# Altium schematic sheet KETCube_2.SchDoc
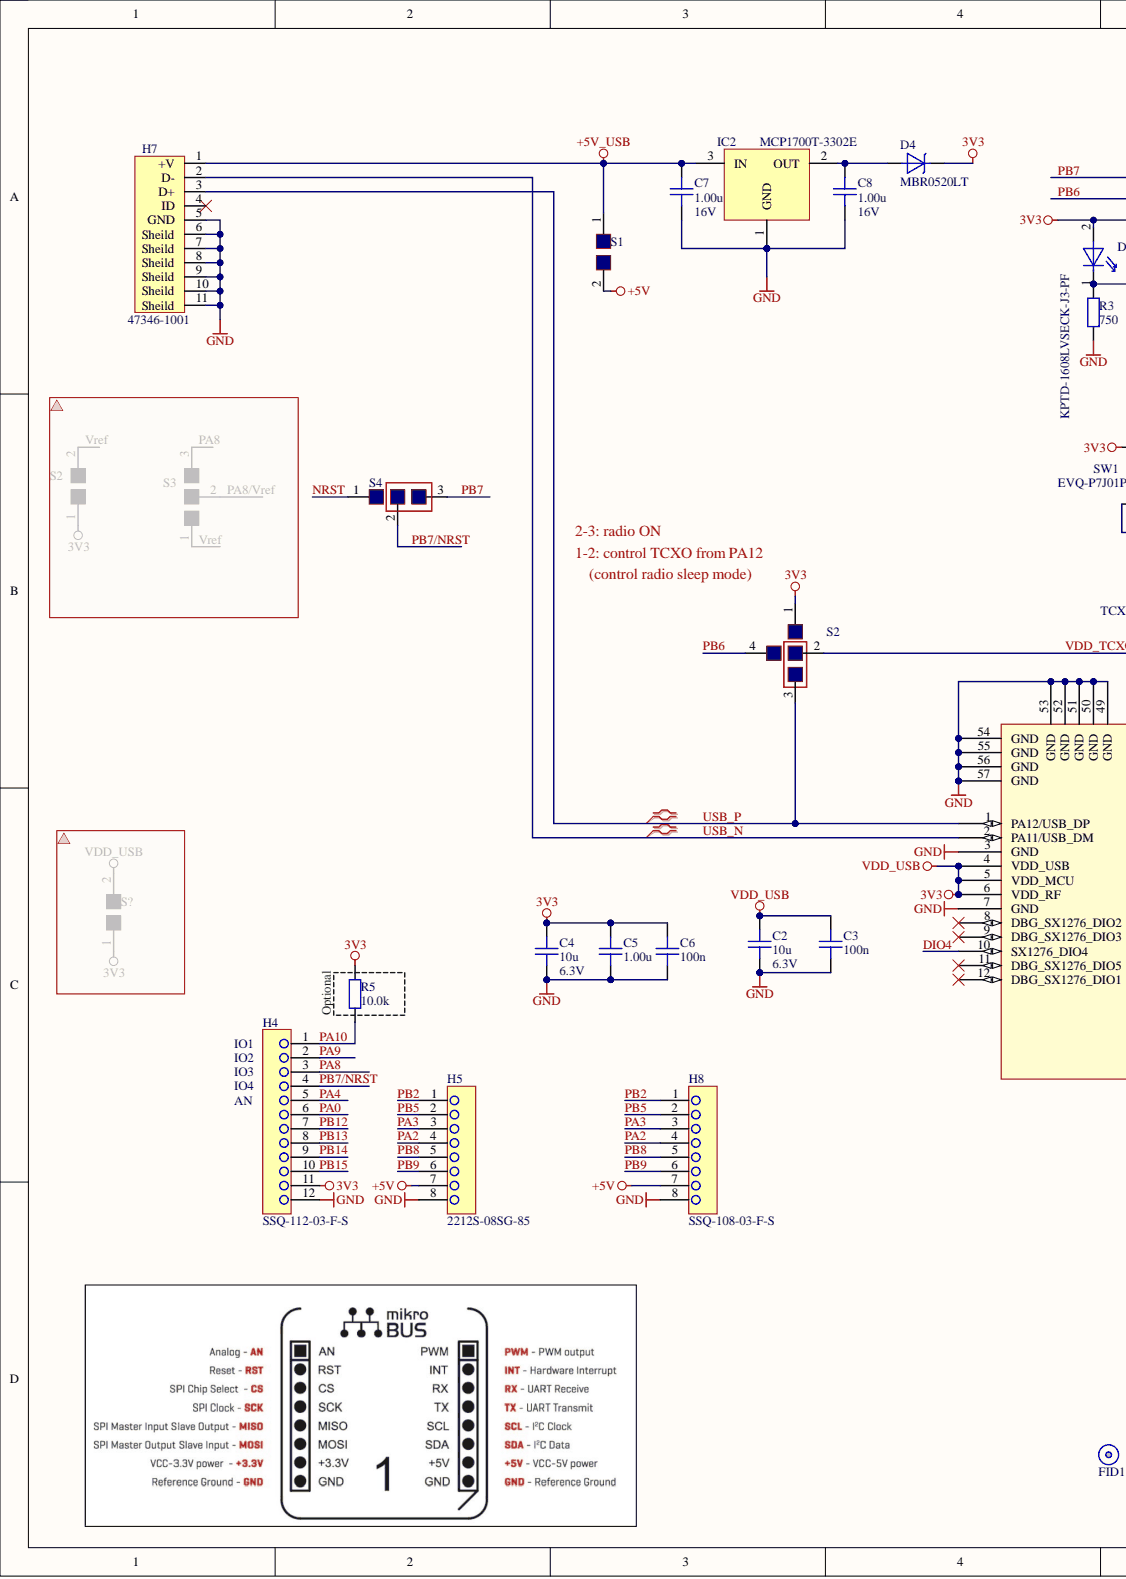
[diagram p0: KETCube_2.SchDoc, left]
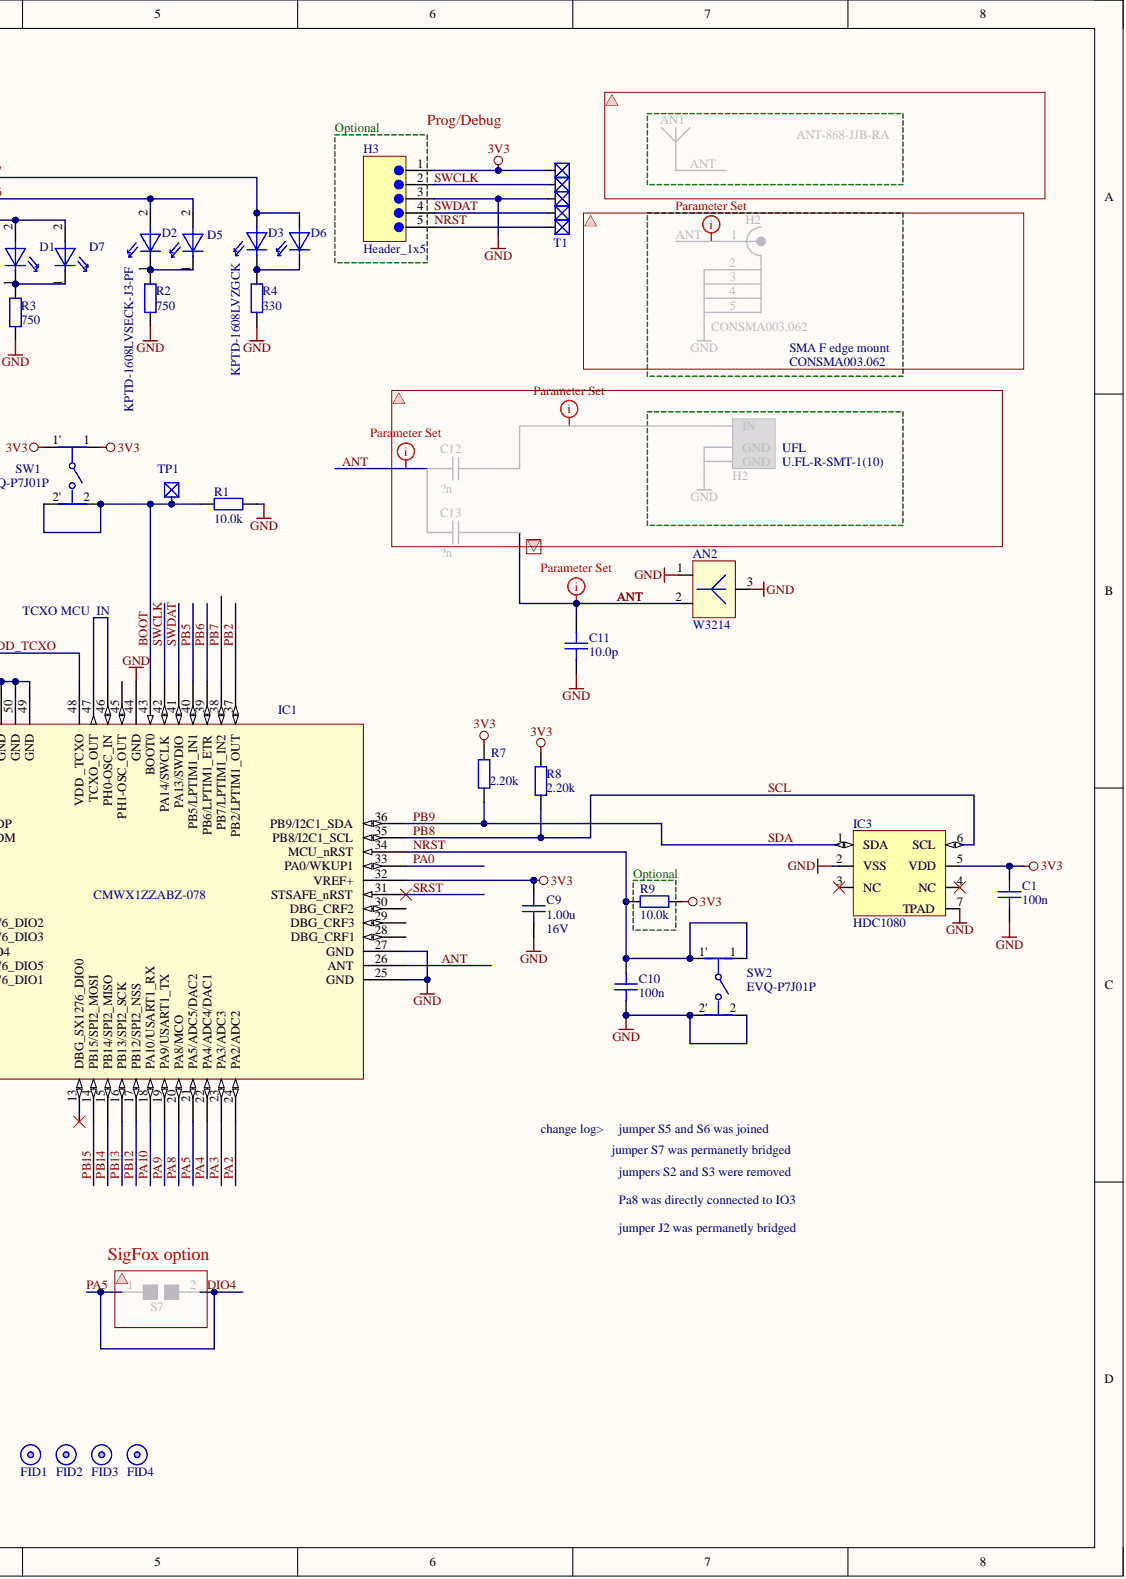
[diagram p1: KETCube_2.SchDoc, right]

## Parts
AN2  value W3214  Manuf Part Number: W3214  [SM_ANT_W3214]  (hidden comment: ant)
C1  100n  [sm_cap_0603]
C2  10u  Voltage: 6.3V  [sm_cap_0603]
C3  100n  [sm_cap_0603]
C4  10u  Voltage: 6.3V  [sm_cap_0603]
C5  1.00u  [sm_cap_0603]
C6  100n  [sm_cap_0603]
C7  1.00u  Voltage: 16V  [sm_cap_0603]
C8  1.00u  Voltage: 16V  [sm_cap_0603]
C9  1.00u  Voltage: 16V  [sm_cap_0603]
C10  100n  [sm_cap_0603]
C11  10.0p  [sm_cap_0603]
D1  KPTD-1608LVSECK-J3-PF  [sm_opt_led0603_h37_opp]
D2  KPTD-1608LVSECK-J3-PF  [sm_opt_led0603_h37_opp]
D3  KPTD-1608LVZGCK  [sm_opt_led0603_h37]
D4  MBR0520LT  [SM_DIO_SOD123]
D5  KPTD-1608LVSECK-J3-PF  [sm_opt_led0603_h37_opp]
D6  KPTD-1608LVZGCK  [sm_opt_led0603_h37]
D7  KPTD-1608LVSECK-J3-PF  [sm_opt_led0603_h37_opp]
FID1  Fiducial  [SM_mch_FIDUCIAL_32]  (value not drawn)
FID2  Fiducial  [SM_mch_FIDUCIAL_32]  (value not drawn)
FID3  Fiducial  [SM_mch_FIDUCIAL_32]  (value not drawn)
FID4  Fiducial  [SM_mch_FIDUCIAL_32]  (value not drawn)
H3  Header_1x5  [TH_CON_HDR1X5_PIT50_RIGHT]
H4  SSQ-112-03-F-S  [TH_CON_REC1x12_PIT100_L400]
H5  2212S-08SG-85  [TH_CON_REC1x8_PIT100]
H7  47346-1001  [SM_CON_USB_MICRO_B_FEMALE_47346_1001]
H8  SSQ-108-03-F-S  [TH_CON_REC1x8_PIT100_L400]
IC1  CMWX1ZZABZ-078  [TH_MOD_PIN48_LoRa_Murata]
IC2  MCP1700T-3302E  [sm_io_sot23_pin3]
IC3  HDC1080  [SM_IO_WSON6_PIT40]
R1  10.0k  [sm_res_0603]
R2  750  [sm_res_0603]
R3  750  [sm_res_0603]
R4  330  [sm_res_0603]
R5  10.0k  [sm_res_0603]
R7  2.20k  [sm_res_0603]
R8  2.20k  [sm_res_0603]
R9  10.0k  [sm_res_0603]
S1  Solder jumper 0603  [SM_SOLDER_JUMPER_2PIN_0603]  (value not drawn)  (no BOM)
S2  SMD jumper  [SM_SOLDER_JUMPER_4PIN]  (value not drawn)  (no BOM)
S4  SMD jumper  [SM_SOLDER_JUMPER_3PIN]  (value not drawn)  (no BOM)
SW1  EVQ-P7J01P  [FP-EVQ-P7J01P-MFG]
SW2  EVQ-P7J01P  [FP-EVQ-P7J01P-MFG]
T1  TP40_5_pit50  [sm_mch_5pin_pit50]  (value not drawn)  (no BOM)
TP1  TP40  [sm_mch_test40]  (value not drawn)  (no BOM)

## Nets
3V3: C1.2 C2.2 C3.2 C4.2 C5.2 C6.2 C9.2 D1.2 D4.2 D7.2 H3.1 H4.11 IC1.4(VDD_USB) IC1.5(VDD_MCU) IC1.6(VDD_RF) IC1.32(VREF+) IC3.5(VDD) R5.1 R7.2 R8.2 R9.1 S2.1 SW1.1 SW1.1' T1.1
+5V: H5.7 H8.7 S1.2
+5V_USB: C7.2 H7.1(+V) IC2.3 S1.1
GND: AN2.1 AN2.3 C1.1 C2.1 C3.1 C4.1 C5.1 C6.1 C7.1 C8.1 C9.1 C10.1 C11.1 H3.3 H4.12 H5.8 H7.5(GND) H7.6(Sheild) H7.7(Sheild) H7.8(Sheild) H7.9(Sheild) H7.10(Sheild) H7.11(Sheild) H8.8 IC1.3(GND) IC1.7(GND) IC1.25(GND) IC1.27(GND) IC1.44(GND) IC1.49(GND) IC1.50(GND) IC1.51(GND) IC1.52(GND) IC1.53(GND) IC1.54(GND) IC1.55(GND) IC1.56(GND) IC1.57(GND) IC2.1 IC3.2(VSS) IC3.7(TPAD) R1.1 R2.2 R3.1 R4.2 SW2.2 SW2.2' T1.3
ANT: AN2.2 C11.2 IC1.26(ANT)
BOOT: IC1.43(BOOT0) R1.2 SW1.2(2') SW1.2' TP1.1
DIO4: IC1.10(SX1276_DIO4) IC1.21(PA5/ADC5/DAC2)
NRST: C10.2 H3.5 IC1.34(MCU_nRST) R9.2 S4.1 SW2.1 SW2.1' T1.5
PA0: H4.6 IC1.33(PA0/WKUP1)
PA2: H5.4 H8.4 IC1.24(PA2/ADC2)
PA3: H5.3 H8.3 IC1.23(PA3/ADC3)
PA4: H4.5 IC1.22(PA4/ADC4/DAC1)
PA8: H4.3 IC1.20(PA8/MCO)
PA9: H4.2 IC1.19(PA9/USART1_TX)
PA10: H4.1 IC1.18(PA10/USART1_RX) R5.2
PB2: H5.1 H8.1 IC1.37(PB2/LPTIM1_OUT)
PB5: H5.2 H8.2 IC1.40(PB5/LPTIM1_IN1)
PB6: D2.2 D5.2 IC1.39(PB6/LPTIM1_ETR) S2.4
PB7: D3.1 D6.1 IC1.38(PB7/LPTIM1_IN2) S4.3
PB7/NRST: H4.4 S4.2
PB8: H5.5 H8.5 IC1.35(PB8/I2C1_SCL) IC3.6(SCL) R8.1
PB9: H5.6 H8.6 IC1.36(PB9/I2C1_SDA) IC3.1(SDA) R7.1
PB12: H4.7 IC1.17(PB12/SPI2_NSS)
PB13: H4.8 IC1.16(PB13/SPI2_SCK)
PB14: H4.9 IC1.15(PB14/SPI2_MISO)
PB15: H4.10 IC1.14(PB15/SPI2_MOSI)
SRST: IC1.31(STSAFE_nRST)
SWCLK: H3.2 IC1.42(PA14/SWCLK) T1.2
SWDAT: H3.4 IC1.41(PA13/SWDIO) T1.4
USB_N: H7.2(D-) IC1.2(PA11/USB_DM)
USB_P: H7.3(D+) IC1.1(PA12/USB_DP) S2.3
VDD_TCXO: IC1.48(VDD__TCXO) S2.2
NetC8_2: C8.2 D4.1 IC2.2
NetD1_1: D1.1 D7.1 R3.2
NetD2_1: D2.1 D5.1 R2.1
NetD3_2: D3.2 D6.2 R4.1
NetIC1_46: IC1.46(PH0-OSC_IN) IC1.47(TCXO_OUT)

Not connected: H7 4(ID); IC1 8(DBG_SX1276_DIO2) 9(DBG_SX1276_DIO3) 11(DBG_SX1276_DIO5) 12(DBG_SX1276_DIO1) 13(DBG_SX1276_DIO0) 28(DBG_CRF1) 29(DBG_CRF3) 30(DBG_CRF2) 45(PH1-OSC_OUT); IC3 3(NC) 4(NC)

## Library data of the parts
AN2 = MOD_000054: MOD antenna CHIP 863-873 MHz, ISM, IoT, 10x3.2x5mm; Manufacturer: Pulse Electronics; ManufacturerID: Pulse; Part Select: SMOD0006000000000000; Supp Part number: 673-W3214; Supp Part number 2: 1837-1154-1-ND; Active: 1; Database Table Name: _Modules; Designer: Jiri Cengery; Exact price 2: 0; FIT: 2; ID: 7218; LasrRevisionNote: update; Max Oper Temperature: 85; Min Oper Temperature: -40; PCB Status: electrical; Publishied: 15,9,2019; Alternative: 1; Cat1: Antenna; Component Kind: Standard; ComponentLink1Description: Datasheet; ComponentLink1URL: https://riceproject.fel.zcu.cz/Altium/PDF/Passive/antennas/PulseLarsen/2719935.pdf; ComponentLink2Description: Antenna 868MHz; Exact price: 1; Exact price 3: 0; LastRevisionDate: 31.10.2019; Library Name: Lib_Components_SQL.DbLib; ManufacturerURL: http://www.pulseelectronics.com; Part Category: Antenna; Part Number: MOD_000054; Part type: MOD; PartNumber: MOD_000054; PartQuantity: 1; Preference: 0; Price: 41; Price 2: 41; Price 3: 0; RoHS: 1; Simulation: nosim; Stock: 0; Supplier: Mouser Electronics; Supplier2: Digikey; Supplier3: N/A; SupplierID: Mouser; SupplierID2: Digikey; SupplierID3: no supplier; SupplierURL: http://www.mouser.com; SupplierURL2: http://www.digikey.com/; SupplierURL3: N/A; Publisher: Jiri Cengery
C1 C3 C6 C10 = CAP_000000: CAP CER .10UF 50V X7R 10% 0603; Manuf Part Number: C1608X7R1H104K080AA; Manufacturer: TDK Corporation; ManufacturerID: TDK; Part Category: Ceramic/0603/X7R; Part Select: SCAP0001000300026100; Supp Part number: 445-1314-2-ND; Supp Part number 2: 1907318; Active: 1; Cat1: Ceramic; Cat2: 0603; Database Table Name: _Capacitors; Designer: Jiri  Cengery; Exact price 2: 0; FIT: 0,100000001490116; ID: 4; LasrRevisionNote: new release; Max Oper Temperature: 125; Min Oper Temperature: -55; PCB Status: Electrical; Preference: 100; Alternative: 1; Cat3: X7R; Component Kind: Standard; ComponentLink1Description: Datasheet; ComponentLink1URL: https://riceproject.fel.zcu.cz/Altium/PDF/passive\capacitors\Cxxxx_TDK.pdf; Dielectric: X7R; Exact price: 1; Exaxt price 3: 0; LastRevisionDate: 11.5.2011; Library Name: Lib_Components_SQL.DbLib; ManufacturerURL: http://www.tdk.com/; Part Number: CAP_000000; Part type: CAP; PartNumber: CAP_000000; PartQuantity: 1; PCB3D: sm_cap_0603; Price: 0,112099997699261; Price 2: 0,899999976158142; Price 3: 0; Publishied: 11.5.2011; Quantity: 1; ROHS: 1; Simulation: cap; Stock: 2901; Supplier: Digikey; Supplier2: Farnell; Supplier3: N/A; SupplierID: Digikey; SupplierID2: Farnell; SupplierID3: no supplier; SupplierURL: http://www.digikey.com/; SupplierURL2: http://cz.farnell.com/; SupplierURL3: N/A; Tolerance: 10%; Value: 100n; Voltage: 50V; Publisher: Libor Polacek
C2 C4 = CAP_000536: CAP CER 10uF 6.3V X5R 10% 0603; Manuf Part Number: GRM188R60J106KE47D; Manufacturer: Murata; Part Category: Ceramic/0603/X5R; Part Select: SCAP0001000300038100; Supp Part number: 2494230; Active: 1; Cat1: Ceramic; Cat2: 0603; Database Table Name: _Capacitors; Designer: Jiri  Cengery; Exact price 2: 0; FIT: 0,100000001490116; ID: 5366; LastRevisionNote: new release; Max Oper Temperature: 85; Min Oper Temperature: -55; PCB Status: Electrical; Alternative: 1; Cat3: X5R; Component Kind: Standard; ComponentLink1Description: Datasheet; ComponentLink1URL: https://riceproject.fel.zcu.cz/Altium/PDF/Passive\Capacitors\1955679.pdf; Dielectric: X5R; Exact price: 1; Exact price 3: 0; LastRevisionDate: 11.5.2011; Library Name: Lib_Components_SQL.DbLib; ManufacturerID: Murata; ManufacturerURL: http://www.murata.com/; Part Number: CAP_000536; Part type: CAP; PartNumber: CAP_000536; PartQuantity: 1; PCB3D: sm_cap_0603; Preference: 0; Price: 1; Price 2: 0; Price 3: 0; Published: 11.5.2011; RoHS: 1; Simulation: cap; Stock: 0; Supplier: Farnell; Supplier2: N/A; Supplier3: N/A; SupplierID: Farnell; SupplierID2: no supplier; SupplierID3: no supplier; SupplierURL: http://cz.farnell.com/; SupplierURL2: N/A; SupplierURL3: N/A; Tolerance: 10%; Value: 10u; Publisher: Libor Polacek
C5 C9 = CAP_000381: CAP CER 1.0UF 16V X7R 10% 0603; Manuf Part Number: C1608X7R1C105K; Manufacturer: TDK Corporation; ManufacturerID: TDK; Part Category: Ceramic/0603/X7R; Part Select: SCAP0001000300027100; Supp Part number: 445-1604-1-ND; Supp Part number 2: 1907343; Active: 1; Cat1: Ceramic; Cat2: 0603; Database Table Name: _Capacitors; Designer: Jiri  Cengery; Exact price 2: 0; FIT: 0,100000001490116; ID: 1567; LastRevisionNote: new release; Max Oper Temperature: 125; Min Oper Temperature: -55; PCB Status: Electrical; Alternative: 1; Cat3: X7R; Component Kind: Standard; ComponentLink1Description: Datasheet; ComponentLink1URL: https://riceproject.fel.zcu.cz/Altium/PDF/Passive\Capacitors\C_series_TDK.pdf; Dielectric: X7R; Exact price: 1; Exaxt price 3: 0; LastRevisionDate: 11.5.2011; Library Name: Lib_Components_SQL.DbLib; ManufacturerURL: http://www.tdk.com/; Part Number: CAP_000381; Part type: CAP; PartNumber: CAP_000381; PartQuantity: 1; PCB3D: sm_cap_0603; Preference: 0; Price: 1,10000002384186; Price 2: 25; Price 3: 0; Published: 11.5.2011; ROHS: 1; Simulation: cap; Stock: 3184; Supplier: Digikey; Supplier2: Farnell; Supplier3: N/A; SupplierID: Digikey; SupplierID2: Farnell; SupplierID3: no supplier; SupplierURL: http://www.digikey.com/; SupplierURL2: http://cz.farnell.com/; SupplierURL3: N/A; Tolerance: 10%; Value: 1.00u; Publisher: Libor Polacek
  C5: Voltage: 16V
C7 C8 = CAP_000381: CAP CER 1.0UF 16V X7R 10% 0603; Manuf Part Number: C1608X7R1C105K; Manufacturer: TDK Corporation; ManufacturerID: TDK; Part Category: Ceramic/0603/X7R; Part Select: SCAP0001000300027100; Supp Part number: 445-1604-1-ND; Supp Part number 2: 1907343; Active: 1; Cat1: Ceramic; Cat2: 0603; Database Table Name: _Capacitors; Designer: Jiri  Cengery; Exact price 2: 0; FIT: 0.100000001490116; ID: 1567; LastRevisionNote: new release; Max Oper Temperature: 125; Min Oper Temperature: -55; PCB Status: Electrical; Alternative: 1; Cat3: X7R; Component Kind: Standard; ComponentLink1Description: Datasheet; ComponentLink1URL: https://riceproject.fel.zcu.cz/Altium/PDF/Passive\Capacitors\C_series_TDK.pdf; Dielectric: X7R; Exact price: 1; Exact price 3: 0; LastRevisionDate: 11.5.2011; Library Name: Lib_Components_SQL.DbLib; ManufacturerURL: http://www.tdk.com/; Part Number: CAP_000381; Part type: CAP; PartNumber: CAP_000381; PartQuantity: 1; PCB3D: sm_cap_0603; Preference: 0; Price: 1.10000002384186; Price 2: 25; Price 3: 0; Published: 11.5.2011; RoHS: 1; Simulation: cap; Stock: 3181; Supplier: Digikey; Supplier2: Farnell; Supplier3: N/A; SupplierID: Digikey; SupplierID2: Farnell; SupplierID3: no supplier; SupplierURL: http://www.digikey.com/; SupplierURL2: http://cz.farnell.com/; SupplierURL3: N/A; Tolerance: 10%; Value: 1.00u; Publisher: Libor Polacek
C11 = CAP_000016: CAP CER 10.0p 50V C0G 5% 0603; Manuf Part Number: GRM1885C1H100JA01D; Manufacturer: Murata; Part Category: Ceramic/0603/COG/NPO; Part Select: SCAP0001000300012100; Supp Part number: 490-1403-2-ND; Active: 1; Cat1: Ceramic; Cat2: 0603; Cat3: COG/NPO; Database Table Name: _Capacitors; Designer: MaJa; FIT: 0,100000001490116; ID: 20; LasrRevisionNote: new release; Max Oper Temperature: 125; Min Oper Temperature: -55; PCB Status: Electrical; Alternative: 1; Component Kind: Standard; ComponentLink1Description: Datasheet; ComponentLink1URL: https://riceproject.fel.zcu.cz/Altium/PDF/passive/capacitors/GRMxxx_Murata.pdf; Dielectric: C0G; Exact price: 1; LastRevisionDate: 11.5.2011; Library Name: Lib_Components_SQL.DbLib; ManufacturerID: Murata; ManufacturerURL: http://www.murata.com/; Part Number: CAP_000016; Part type: CAP; PartNumber: CAP_000016; PartQuantity: 1; PCB3D: sm_cap_0603; Price: 0,103799998760223; Price 2: 0; Price 3: 0; Publishied: 11.5.2011; Quantity: 1; RoHS: 1; Simulation: cap; Stock: 45; Supplier: Digikey; Supplier2: N/A; Supplier3: N/A; SupplierID: Digikey; SupplierID2: no supplier; SupplierID3: no supplier; SupplierURL: http://www.digikey.com/; SupplierURL2: N/A; SupplierURL3: N/A; Tolerance: 5%; Value: 10.0p; Voltage: 50V; Publisher: Libor Polacek
D1 D2 D5 D7 = OPT_000081: OPT LED red 625nm 2mA 50mcd 1.8V 0603; Manufacturer: kingbright; Part Category: LED/0603; Part Select: SOPT0001000100000000; Supp Part number: 2610411; Active: 1; Cat2: 0603; Database Table Name: _Optoelectronics; Designer: Jiri  Cengery; Exact price: 0; FIT: 2; ID: 6052; LastRevisionNote: new release; Max Oper Temperature: 85; Min Oper Temperature: -40; PCB Status: Electrical; Alternative: 1; Cat1: LED; Color: red; Component Kind: Standard; ComponentLink1Description: Datasheet; ComponentLink1URL: https://riceproject.fel.zcu.cz/Altium/PDF/Semiconductor\Analog\LED\2068425.pdf; Exact price 2: 0; Exaxt price 3: 0; LastRevisionDate: 11.5.2011; Library Name: Lib_Components_SQL.DbLib; Manuf Part Number: KPTD-1608LVSECK-J3-PF; ManufacturerID: Kingbright; ManufacturerURL: www.kingbright.com; Part Number: OPT_000081; Part type: OPT; PartNumber: OPT_000081; PartQuantity: 1; PCB3D: sm_opt_led0603_h37_opp; Preference: 0; Price: 7; Price 2: 0; Price 3: 0; Published: 11.5.2011; Simulation: nosim; Stock: 0; Supplier: Farnell; Supplier2: N/A; Supplier3: N/A; SupplierID: Farnell; SupplierID2: no supplier; SupplierID3: no supplier; SupplierURL: http://cz.farnell.com/; SupplierURL2: N/A; SupplierURL3: N/A; Value: KPTD-1608LVSECK-J3-PF; Publisher: Libor Polacek
D3 D6 = OPT_000080: OPT LED green 525nm 2mA 220mcd 2.65V 0603; Manufacturer: kingbright; Part Category: LED/0603; Part Select: SOPT0001000100000000; Supp Part number: 2610414; Active: 1; Cat2: 0603; Database Table Name: _Optoelectronics; Designer: Jiri  Cengery; Exact price: 0; FIT: 2; ID: 6050; LastRevisionNote: new release; Max Oper Temperature: 85; Min Oper Temperature: -40; PCB Status: Electrical; Alternative: 1; Cat1: LED; Color: green; Component Kind: Standard; ComponentLink1Description: Datasheet; ComponentLink1URL: https://riceproject.fel.zcu.cz/Altium/PDF/Semiconductor\Analog\LED\2068428.pdf; Exact price 2: 0; Exaxt price 3: 0; LastRevisionDate: 11.5.2011; Library Name: Lib_Components_SQL.DbLib; Manuf Part Number: KPTD-1608LVZGCK; ManufacturerID: Kingbright; ManufacturerURL: www.kingbright.com; Part Number: OPT_000080; Part type: OPT; PartNumber: OPT_000080; PartQuantity: 1; PCB3D: sm_opt_led0603_h37; Preference: 0; Price: 8; Price 2: 0; Price 3: 0; Published: 11.5.2011; Simulation: nosim; Stock: 0; Supplier: Farnell; Supplier2: N/A; Supplier3: N/A; SupplierID: Farnell; SupplierID2: no supplier; SupplierID3: no supplier; SupplierURL: http://cz.farnell.com/; SupplierURL2: N/A; SupplierURL3: N/A; Value: KPTD-1608LVZGCK; Publisher: Libor Polacek
D4 = DIO_000074: DIO schottky  20V 0.5A SOD123; Manuf Part Number: MBR0520LT1G; Manufacturer: On Semiconductor; ManufacturerID: OnSemi; Part Select: SDIO0002000000000000; Supp Part number: MBR0520LCT-ND; Active: 1; Database Table Name: _Diodes; Designer: Libor Polacek; Exact price 2: 0; FIT: 2; ID: 4063; LastRevisionNote: new release; Max Oper Temperature: 125; Min Oper Temperature: -55; PCB Status: Electrical; Alternative: 1; Cat1: Schottky; Component Kind: Standard; ComponentLink1Description: Datasheet; ComponentLink1URL: https://riceproject.fel.zcu.cz/Altium/PDF/Semiconductor\Analog\Diode\MBR0520LT1-D.PDF; Current: 0.5A; Exact price: 1; Exaxt price 3: 0; LastRevisionDate: 11.5.2011; Library Name: Lib_Components_SQL.DbLib; ManufacturerURL: http://www.onsemi.com; Part Category: Schottky; Part Number: DIO_000074; Part type: DIO; PartNumber: DIO_000074; PartQuantity: 1; Preference: 0; Price: 7; Price 2: 0; Price 3: 0; Published: 11.5.2011; ROHS: 1; Simulation: nosim; Stock: 0; Supplier: Digikey; Supplier2: N/A; Supplier3: N/A; SupplierID: Digikey; SupplierID2: no supplier; SupplierID3: no supplier; SupplierURL: http://www.digikey.com/; SupplierURL2: N/A; SupplierURL3: N/A; Value: MBR0520LT; Voltage: 20V; Publisher: Libor Polacek
FID1-FID4 = MCH_000050: Fiducial local circle 0.8mm; Manuf Part Number: x; ManufacturerID: no manufacturer; Part Category: Virtual/Fiducial; Part Select: SMCH0002000200000000; Active: 1; Cat1: Virtual; Database Table Name: _Mechanicals; Designer: Libor Polacek; Exact price: 0; ID: 2608; LastRevisionNote: new release; Max Oper Temperature: 200; Min Oper Temperature: -60; Alternative: 1; Cat2: Fiducial; Component Kind: Standard; ComponentLink1Description: Datasheet; Exact price 2: 0; Exaxt price 3: 0; FIT: 0; Function Type: fiducial; LastRevisionDate: 8.9.2011; Library Name: Lib_Components_SQL.DbLib; Part Number: MCH_000050; Part type: MCH; PartNumber: MCH_000050; PartQuantity: 1; PCB3D: sm_vir_fiducial; PCB Status: Virtual; Preference: 0; Price: 0; Price 2: 0; Price 3: 0; Publishied: 8.9.2011; ROHS: 1; Simulation: nosim; Stock: 0; Supp Part number: x; Supplier: N/A; Supplier2: N/A; Supplier3: N/A; SupplierID: no supplier; SupplierID2: no supplier; SupplierID3: no supplier; SupplierURL: N/A; SupplierURL2: N/A; SupplierURL3: N/A; Value: Fiducial; Publisher: Libor Polacek
H3 = CON_000371: CON 1x5pin header 50mill, righ , L 4mm; Manuf Part Number: TMS-105-02-L-S-RA; Manufacturer: SAMTEC; Part Category: Pin rows/Header PIT50/Position; Part Select: TCON0001000600015001; Supp Part number: 2055114; Active: 1; Cat1: Pin rows; Cat2: Header PIT50; Cat3: Position; Database Table Name: _Connectors; Designer: Jiri  Cengery; Exact price 2: 0; FIT: 5; ID: 5632; Max Oper Temperature: 105; Min Oper Temperature: -40; PCB Status: Electrical; Alternative: 1; Component Kind: Standard; ComponentLink1Description: Datasheet; ComponentLink1URL: https://riceproject.fel.zcu.cz/Altium/PDF/Passive\Connectors\Samtec\1992060.pdf; Design: HEADER 50mill; Exact price: 1; Exaxt price 3: 0; LatestRevisionDate: 09-Sep-2011; LatestRevisionNote: Re-released for DXP Platform.; Library Name: Lib_Components_SQL.DbLib; ManufacturerID: SAMTEC; ManufacturerURL: http://www.samtec.com/; Part Number: CON_000371; Part type: CON; PartNumber: CON_000371; PartQuantity: 1; Pin: 5; Preference: 0; Price: 14; Price 2: 0; Price 3: 0; Published: 15.6.2011; ROHS: 1; Signal Integrity: Connector; Simulation: nosim; Stock: 0; Supplier: Farnell; Supplier2: N/A; Supplier3: N/A; SupplierID: Farnell; SupplierID2: no supplier; SupplierID3: no supplier; SupplierURL: http://cz.farnell.com/; SupplierURL2: N/A; SupplierURL3: N/A; Value: Header_1x5; Publisher: Altium Limited
H4 = CON_000361: CON Receptacle 1x10 100 mils, leads length 10mm; Manufacturer: SAMTEC; Part Category: Pin rows/Receptacle/long leads; Part Select: TCON0001000200022120; Supp Part number: 2283784; Active: 1; Cat1: Pin rows; Cat3: long leads; Database Table Name: _Connectors; Design: RECEPTACLE 100mill; Designer: Jiri  Cengery; Exact price 2: 0; FIT: 50; ID: 5578; Max Oper Temperature: 125; Min Oper Temperature: -55; PCB Status: Electrical; System: Connector; Alternative: 1; Cat2: Receptacle; Component Kind: Standard; ComponentLink1Description: Datasheet; ComponentLink1URL: https://riceproject.fel.zcu.cz/Altium/PDF/Passive\Connectors\Samtec\1680445_.pdf; Exact price: 1; Exaxt price 3: 0; LatestRevisionDate: 13.10.2017; LatestRevisionNote: New Release; Library Name: Lib_Components_SQL.DbLib; Manuf Part Number: SSQ-112-03-F-S; ManufacturerID: SAMTEC; ManufacturerURL: http://www.samtec.com/; Part Number: CON_000361; Part type: CON; PartNumber: CON_000361; PartQuantity: 1; PCB3D: TH_CON_REC1x12_PIT100_L400; Pin: 12; Preference: 0; Price: 54; Price 2: 0; Price 3: 0; Published: 13.10.2017; ROHS: 1; Signal Integrity: Connector; Simulation: nosim; Stock: 0; Supplier: Farnell; Supplier2: N/A; Supplier3: N/A; SupplierID: Farnell; SupplierID2: no supplier; SupplierID3: no supplier; SupplierURL: http://cz.farnell.com/; SupplierURL2: N/A; SupplierURL3: N/A; Value: SSQ-112-03-F-S; Publisher: Jiri Cengery
H5 = CON_000370: CON Receptacle 1x8 100 mils, hight 8.5mm; Manufacturer: MCM Electronics; ManufacturerID: Multicomp; Part Category: Pin rows/Receptacle; Part Select: TCON0001000200001800; Supp Part number: 1593463; Active: 1; Cat1: Pin rows; Database Table Name: _Connectors; Design: RECEPTACLE 100mill; Designer: Jiri  Cengery; Exact price 2: 0; FIT: 50; ID: 5624; Max Oper Temperature: 105; Min Oper Temperature: -55; PCB Status: Electrical; System: Connector; Alternative: 1; Cat2: Receptacle; Component Kind: Standard; ComponentLink1Description: Datasheet; ComponentLink1URL: https://riceproject.fel.zcu.cz/Altium/PDF/Passive\Connectors\2290158.pdf; Exact price: 1; Exaxt price 3: 0; LatestRevisionDate: 13.10.2017; LatestRevisionNote: New Release; Library Name: Lib_Components_SQL.DbLib; Manuf Part Number: 2212S-08SG-85; ManufacturerURL: http://www.mcmelectronics.com; Part Number: CON_000370; Part type: CON; PartNumber: CON_000370; PartQuantity: 1; PCB3D: TH_CON_REC1x8_PIT100; Pin: 8; Preference: 0; Price: 23; Price 2: 0; Price 3: 0; Published: 13.10.2017; ROHS: 1; Signal Integrity: Connector; Simulation: nosim; Stock: 0; Supplier: Farnell; Supplier2: N/A; Supplier3: N/A; SupplierID: Farnell; SupplierID2: no supplier; SupplierID3: no supplier; SupplierURL: http://cz.farnell.com/; SupplierURL2: N/A; SupplierURL3: N/A; Value: 2212S-08SG-85; Publisher: Jiri Cengery
H7 = CON_000491: CON USB micro B, USB 2; 5 pins; righ angle, PCB mount SMT; Manufacturer: Molex; Part Category: Comunication/USB; Part Select: SCON0006000100001500; Supp Part number: 2782045; Supp Part number 2: WM11228CT-ND; Active: 1; Cat1: Comunication; Database Table Name: _Connectors; Designer: Jiri Cengery; Exact price: 0; FIT: 4; ID: 7216; LastRevisionNote: new release; Max Oper Temperature: 85; Min Oper Temperature: -30; PCB Status: Electrical; Alternative: 1; Cat2: USB; Component Kind: Standard; ComponentLink1Description: Datasheet; ComponentLink1URL: https://riceproject.fel.zcu.cz/Altium/PDF/Passive/Connectors/USB/2696879.pdf; ComponentLink2Description: Drawing; ComponentLink2URL: https://riceproject.fel.zcu.cz/Altium/PDF/Passive/Connectors/USB/473461001_sd.pdf; Design: USB micro B; Exact price 2: 0; Exact price 3: 0; LastRevisionDate: 12.2.2020; Library Name: Lib_Components_SQL.DbLib; Manuf Part Number: 47346-1001; ManufacturerID: Molex; ManufacturerURL: http://www.molex.com; Part Number: CON_000491; Part type: CON; PartNumber: CON_000491; PartQuantity: 1; Pin: 5; Preference: 0; Price: 14; Price 2: 23; Price 3: 0; Published: 12.2.2020; RoHS: 1; Simulation: nosim; Stock: 0; Supplier: Farnell; Supplier2: Digikey; Supplier3: N/A; SupplierID: Farnell; SupplierID2: Digikey; SupplierID3: no supplier; SupplierURL: http://cz.farnell.com/; SupplierURL2: http://www.digikey.com/; SupplierURL3: N/A; Value: 47346-1001; Publisher: Jiri Cengery
H8 = CON_000358: CON Receptacle 1x8 100 mils, leads length 10mm; Manufacturer: SAMTEC; Part Category: Pin rows/Receptacle/long leads; Part Select: TCON0001000200021800; Supp Part number: 2505051; Active: 1; Cat1: Pin rows; Cat3: long leads; Database Table Name: _Connectors; Design: RECEPTACLE 100mill; Designer: Jiri  Cengery; Exact price 2: 0; FIT: 50; ID: 5560; Max Oper Temperature: 125; Min Oper Temperature: -55; PCB Status: Electrical; System: Connector; Alternative: 1; Cat2: Receptacle; Component Kind: Standard; ComponentLink1Description: Datasheet; ComponentLink1URL: https://riceproject.fel.zcu.cz/Altium/PDF/Passive\Connectors\Samtec\1680445_.pdf; Exact price: 1; Exaxt price 3: 0; LatestRevisionDate: 13.10.2017; LatestRevisionNote: New Release; Library Name: Lib_Components_SQL.DbLib; Manuf Part Number: SSQ-108-03-F-S; ManufacturerID: SAMTEC; ManufacturerURL: http://www.samtec.com/; Part Number: CON_000358; Part type: CON; PartNumber: CON_000358; PartQuantity: 1; PCB3D: TH_CON_REC1x8_PIT100_L400; Pin: 8; Preference: 0; Price: 38; Price 2: 0; Price 3: 0; Published: 13.10.2017; ROHS: 1; Signal Integrity: Connector; Simulation: nosim; Stock: 0; Supplier: Farnell; Supplier2: N/A; Supplier3: N/A; SupplierID: Farnell; SupplierID2: no supplier; SupplierID3: no supplier; SupplierURL: http://cz.farnell.com/; SupplierURL2: N/A; SupplierURL3: N/A; Value: SSQ-108-03-F-S; Publisher: Jiri Cengery
IC1 = MOD_000027: Lora modul Murata (STM32L, 868 MHz, 915 MHz) 12 x 12 mm; Part Category: Comunication/Proprietary; Part Select: SMOD0001000600000000; Supp Part number: 81-CMWX1ZZABZ-078; Active: 1; Cat1: Comunication; Cat2: Proprietary; Database Table Name: _Modules; Designer: Jiri  Cengery; Exact price: 0; FIT: 3; ID: 4764; LasrRevisionNote: new release; Max Oper Temperature: 85; Min Oper Temperature: -40; Alternative: 1; Component Kind: Standard; ComponentLink1Description: Datasheet; ComponentLink1URL: https://riceproject.fel.zcu.cz/Altium/PDF/Semiconductor\Modules\Murata\Murata LoRa Module Preliminary Datasheet SP-ABZ-G.PDF; ComponentLink2Description: Lora modul; Exact price 2: 0; Exaxt price 3: 0; LastRevisionDate: 10.1.2017; Library Name: Lib_Components_SQL.DbLib; Manuf Part Number: CMWX1ZZABZ-078; Manufacturer: Murata; ManufacturerID: Murata; ManufacturerURL: http://www.murata.com/; Part Number: MOD_000027; Part type: MOD; PartNumber: MOD_000027; PartQuantity: 1; Preference: 0; Price: 523; Price 2: 0; Price 3: 0; Publishied: 10.1.2017; ROHS: 1; Simulation: nosim; Stock: 0; Supplier: Mouser Electronics; Supplier2: N/A; Supplier3: N/A; SupplierID: Mouser; SupplierID2: no supplier; SupplierID3: no supplier; SupplierURL: www.mouser.com; SupplierURL2: N/A; SupplierURL3: N/A; Value: CMWX1ZZABZ-078; Publisher: Jiri Cengery
IC2 = ICS_000567: ICS LDO regulator Vout=3.3V, 250mA, Vin=6V, SOT23-3, Vdrop =  200mV; Manuf Part Number: MCP1700T-3302E/TT; Manufacturer: Microchip; Part Category: Analog/Regulator; Part Select: SICS0001000700000000; Supp Part number: 1296592; Active: 1; Cat1: Analog; Database Table Name: _ICs; Designer: Jiri  Cengery; Exact price 2: 0; ID: 4558; LastRevisionNote: new release; Marking: CKCS; Max Oper Temperature: 125; Min Oper Temperature: -40; PCB Status: Electrical; Alternative: 1; Cat2: Regulator; Component Kind: Standard; ComponentLink1Description: Datasheet; ComponentLink1URL: https://riceproject.fel.zcu.cz/Altium/PDF/Semiconductor\Analog\Regulator\1784514.pdf; Exact price: 1; Exaxt price 3: 0; FIT: 1; Function Type: Regulator; LastRevisionDate: 23.9.2016; Library Name: Lib_Components_SQL.DbLib; ManufacturerID: Microchip; ManufacturerURL: http://www.microchip.com/; Part Number: ICS_000567; Part type: ICS; PartNumber: ICS_000567; PartQuantity: 1; Preference: 0; Price: 0; Price 2: 0; Price 3: 0; Published: 23.9.2016; ROHS: 1; Simulation: nosim; Stock: 0; Supplier: Farnell; Supplier2: N/A; Supplier3: N/A; SupplierID: Farnell; SupplierID2: no supplier; SupplierID3: no supplier; SupplierURL: http://cz.farnell.com/; SupplierURL2: N/A; SupplierURL3: N/A; Value: MCP1700T-3302E; Publisher: Jiri Cengery
IC3 = ICS_000724: ICS Low Power, High Accuracy Digital Humidity Sensor with Temperature Sensor; Manuf Part Number: HDC1080DMBT; Manufacturer: Texas Instruments; ManufacturerID: TI; Part Category: Digital/sensor/T+RH; Part Select: SICS0002001600040000; Supp Part number: 2535017; Supp Part number 2: 296-43864-1-ND; Active: 1; Cat3: T+RH; Database Table Name: _ICs; Designer: Jiri  Cengery; Exact price 2: 0; FIT: 500; Function Type: Temperature and RH sensor; ID: 5552; LastRevisionNote: new release; Max Oper Temperature: 125; Min Oper Temperature: -40; PCB Status: Electrical; Alternative: 1; Cat1: Digital; Cat2: sensor; Component Kind: Standard; ComponentLink1Description: Datasheet; ComponentLink1URL: https://riceproject.fel.zcu.cz/Altium/PDF/Semiconductor\Modules\Sensors\hdc1080.pdf; Exact price: 1; Exaxt price 3: 0; LastRevisionDate: 19.2.2016; Library Name: Lib_Components_SQL.DbLib; ManufacturerURL: http://www.ti.com/; Part Number: ICS_000724; Part type: ICS; PartNumber: ICS_000724; PartQuantity: 1; Preference: 0; Price: 78; Price 2: 90; Price 3: 0; Publish: 19.2.2016; ROHS: 1; Simulation: nosim; Stock: 0; Supplier: Farnell; Supplier2: Digikey; Supplier3: N/A; SupplierID: Farnell; SupplierID2: Digikey; SupplierID3: no supplier; SupplierURL: http://cz.farnell.com/; SupplierURL2: http://www.digikey.com/; SupplierURL3: N/A; Technology: CMOS; Value: HDC1080; Publisher: Jiri Cengery
R1 R5 R9 = RES_000097: RES 0603 10.0k 1% 100ppm SMD thick film; Manuf Part Number: ERJ3EKF1002V; Manufacturer: Panasonic; Part Category: Thick film/0603; Part Select: SRES0001000300005100; Supp Part number: P10.0kHTR-ND; Supp Part number 2: 667-ERJ-3EKF1002V; Active: 1; Cat2: 0603; Database Table Name: _Resistors; Designer: Tomáš Košan; Exact price 2: 0; FIT: 0,100000001490116; ID: 458; LastRevisionNote: new release; Max Oper Temperature: 125; Min Oper Temperature: -55; PCB Status: Electrical; Power: 63mW; Alternative: 1; Cat1: Thick film; Component Kind: Standard; ComponentLink1Description: Datasheet; ComponentLink1URL: https://riceproject.fel.zcu.cz/Altium/PDF/passive\resistors\ERJ_EKF_Panasonic.pdf; Exact price: 1; Exaxt price 3: 0; LastRevisionDate: 11.5.2011; Library Name: Lib_Components_SQL.DbLib; ManufacturerID: Panasonic; ManufacturerURL: http://www.industrial.panasonic.com/; Part Number: RES_000097; Part type: RES; PartNumber: RES_000097; PartQuantity: 1; PCB3D: sm_res_0603; Preference: 0; Price: 0,0044999998062849; Price 2: 2,4300000667572; Price 3: 0; Published: 11.5.2011; Quantity: 1; ROHS: 1; Simulation: res; Stock: 985; Supplier: Digikey; Supplier2: Mouser Electronics; Supplier3: N/A; SupplierID: Digikey; SupplierID2: Mouser; SupplierID3: no supplier; SupplierURL: http://www.digikey.com/; SupplierURL2: www.mouser.com; SupplierURL3: N/A; Thermal Stability: 100ppm; Tolerance: 1%; Value: 10.0k; Publisher: Libor Polacek
R2 R3 = RES_000070: RES 0603 750R 1% 100ppm SMD thick film; Manuf Part Number: ERJ3EKF7500V; Manufacturer: Panasonic; Part Category: Thick film/0603; Part Select: SRES0001000300003750; Supp Part number: P750HTR-ND; Active: 1; Cat2: 0603; Database Table Name: _Resistors; Designer: LiPo; FIT: 0,100000001490116; ID: 431; LastRevisionNote: new release; Max Oper Temperature: 125; Min Oper Temperature: -55; PCB Status: Electrical; Power: 63mW; Alternative: 1; Cat1: Thick film; Component Kind: Standard; ComponentLink1Description: Datasheet; ComponentLink1URL: https://riceproject.fel.zcu.cz/Altium/PDF/passive\resistors\ERJ_EKF_Panasonic.pdf; Exact price: 1; LastRevisionDate: 11.5.2011; Library Name: Lib_Components_SQL.DbLib; ManufacturerID: Panasonic; ManufacturerURL: http://www.industrial.panasonic.com/; Part Number: RES_000070; Part type: RES; PartNumber: RES_000070; PartQuantity: 1; PCB3D: sm_res_0603; Price: 0,0044999998062849; Price 2: 0; Price 3: 0; Published: 11.5.2011; Quantity: 1; ROHS: 1; Simulation: res; Stock: 0; Supplier: Digikey; Supplier2: N/A; Supplier3: N/A; SupplierID: Digikey; SupplierID2: no supplier; SupplierID3: no supplier; SupplierURL: http://www.digikey.com/; SupplierURL2: N/A; SupplierURL3: N/A; Thermal Stability: 100ppm; Tolerance: 1%; Value: 750; Publisher: Libor Polacek
R4 = RES_000061: RES 0603 330R 1% 100ppm SMD thick film; Manuf Part Number: ERJ3EKF3300V; Manufacturer: Panasonic; Part Category: Thick film/0603; Part Select: SRES0001000300003330; Supp Part number: P330HTR-ND; Active: 1; Cat2: 0603; Database Table Name: _Resistors; Designer: LiPo; FIT: 0,100000001490116; ID: 422; LastRevisionNote: new release; Max Oper Temperature: 125; Min Oper Temperature: -55; PCB Status: Electrical; Power: 63mW; Alternative: 1; Cat1: Thick film; Component Kind: Standard; ComponentLink1Description: Datasheet; ComponentLink1URL: https://riceproject.fel.zcu.cz/Altium/PDF/passive\resistors\ERJ_EKF_Panasonic.pdf; Exact price: 1; LastRevisionDate: 11.5.2011; Library Name: Lib_Components_SQL.DbLib; ManufacturerID: Panasonic; ManufacturerURL: http://www.industrial.panasonic.com/; Part Number: RES_000061; Part type: RES; PartNumber: RES_000061; PartQuantity: 1; PCB3D: sm_res_0603; Price: 0,0044999998062849; Price 2: 0; Price 3: 0; Published: 11.5.2011; Quantity: 1; ROHS: 1; Simulation: res; Stock: 4327; Supplier: Digikey; Supplier2: N/A; Supplier3: N/A; SupplierID: Digikey; SupplierID2: no supplier; SupplierID3: no supplier; SupplierURL: http://www.digikey.com/; SupplierURL2: N/A; SupplierURL3: N/A; Thermal Stability: 100ppm; Tolerance: 1%; Value: 330; Publisher: Libor Polacek
R7 R8 = RES_000081: RES 0603 2.20k 1% 100ppm SMD thick film; Manuf Part Number: ERJ3EKF2201V; Manufacturer: Panasonic; Part Category: Thick film/0603; Part Select: SRES0001000300004220; Supp Part number: P2.20kHTR-ND; Active: 1; Cat2: 0603; Database Table Name: _Resistors; Designer: LiPo; FIT: 0,100000001490116; ID: 442; LastRevisionNote: new release; Max Oper Temperature: 125; Min Oper Temperature: -55; PCB Status: Electrical; Power: 63mW; Alternative: 1; Cat1: Thick film; Component Kind: Standard; ComponentLink1Description: Datasheet; ComponentLink1URL: https://riceproject.fel.zcu.cz/Altium/PDF/passive\resistors\ERJ_EKF_Panasonic.pdf; Exact price: 1; LastRevisionDate: 11.5.2011; Library Name: Lib_Components_SQL.DbLib; ManufacturerID: Panasonic; ManufacturerURL: http://www.industrial.panasonic.com/; Part Number: RES_000081; Part type: RES; PartNumber: RES_000081; PartQuantity: 1; PCB3D: sm_res_0603; Price: 0,0044999998062849; Price 2: 0; Price 3: 0; Published: 11.5.2011; Quantity: 1; ROHS: 1; Simulation: res; Stock: 853; Supplier: Digikey; Supplier2: N/A; Supplier3: N/A; SupplierID: Digikey; SupplierID2: no supplier; SupplierID3: no supplier; SupplierURL: http://www.digikey.com/; SupplierURL2: N/A; SupplierURL3: N/A; Thermal Stability: 100ppm; Tolerance: 1%; Value: 2.20k; Publisher: Libor Polacek
S1 = MCH_000103: Solder jumper 2pin; simple type; ManufacturerID: no manufacturer; Part Category: Virtual/Pattern; Part Select: SMCH0002000300000000; Active: 1; Cat1: Virtual; Cat2: Pattern; Database Table Name: _Mechanicals; Designer: Jiri  Cengery; Exact price 2: 0; FIT: 9,99999974737875E-6; ID: 5562; Max Oper Temperature: 125; Min Oper Temperature: -45; Alternative: 1; ComponentLink1Description: Datasheet; Exact price: 1; Exaxt price 3: 0; Function Type: Solder jumper; LatestRevisionDate: 22.1.2012; LatestRevisionNote: new release; Library Name: Lib_Components_SQL.DbLib; Part Number: MCH_000103; Part type: MCH; PartNumber: MCH_000103; PartQuantity: 1; PCB Status: Virtual; Preference: 0; Price: 0; Price 2: 0; Price 3: 0; Published: 22.1.2012; ROHS: 1; Simulation: nosim; Stock: 0; Supplier: N/A; Supplier2: N/A; Supplier3: N/A; SupplierID: no supplier; SupplierID2: no supplier; SupplierID3: no supplier; SupplierURL: N/A; SupplierURL2: N/A; SupplierURL3: N/A; Value: Solder jumper 0603; Publisher: Tomas Kosan
S2 = (no symbol name): Solder jumper 2pin; simple type; ManufacturerID: no manufacturer; Part Category: Virtual/Pattern; Part Number: MCH_000104; Part Select: SMCH0002000300000000; Active: 1; Cat1: Virtual; Cat2: Pattern; Designer: Jiri  Cengery; Exact price 2: 0; FIT: 9,99999974737875E-6; Function Type: Solder jumper 3PIN; ID: 5870; Max Oper Temperature: 125; Min Oper Temperature: -45; Value: Solder jumper 3PIN small; Alternative: 1; ComponentLink1Description: Datasheet; Exact price: 1; Exaxt price 3: 0; LatestRevisionDate: 22.1.2012; LatestRevisionNote: new release; Part type: MCH; PartNumber: MCH_000104; PartQuantity: 1; PCB Status: Virtual; Preference: 0; Price: 0; Price 2: 0; Price 3: 0; Published: 22.1.2012; ROHS: 1; Simulation: nosim; Stock: 0; Supplier: N/A; Supplier2: N/A; Supplier3: N/A; SupplierID: no supplier; SupplierID2: no supplier; SupplierID3: no supplier; SupplierURL: N/A; SupplierURL2: N/A; SupplierURL3: N/A; Library Name: KETCube2_Lora_Murata_uBus.SCHLIB; Publisher: Tomas Kosan
S4 = MCH_000104: Solder jumper 2pin; simple type; ManufacturerID: no manufacturer; Part Category: Virtual/Pattern; Part Select: SMCH0002000300000000; Active: 1; Cat1: Virtual; Cat2: Pattern; Database Table Name: _Mechanicals; Designer: Jiri  Cengery; Exact price 2: 0; FIT: 9,99999974737875E-6; Function Type: Solder jumper 3PIN; ID: 5870; Max Oper Temperature: 125; Min Oper Temperature: -45; Value: Solder jumper 3PIN small; Alternative: 1; ComponentLink1Description: Datasheet; Exact price: 1; Exaxt price 3: 0; LatestRevisionDate: 22.1.2012; LatestRevisionNote: new release; Library Name: Lib_Components_SQL.DbLib; Part Number: MCH_000104; Part type: MCH; PartNumber: MCH_000104; PartQuantity: 1; PCB Status: Virtual; Preference: 0; Price: 0; Price 2: 0; Price 3: 0; Published: 22.1.2012; ROHS: 1; Simulation: nosim; Stock: 0; Supplier: N/A; Supplier2: N/A; Supplier3: N/A; SupplierID: no supplier; SupplierID2: no supplier; SupplierID3: no supplier; SupplierURL: N/A; SupplierURL2: N/A; SupplierURL3: N/A; Publisher: Tomas Kosan
SW1 SW2 = CMP-05618-000001-1: SWITCH TACTILE SPST-NO 0.05A 12V; Manufacturer: Panasonic; Supp Part number: 2860918; Contact Current Rating: 50mA; Contact Resistance: 500mΩ; Electromechanical Life: 100000Cycles; Operating Force: 1.6N; Automotive: No; Category: Switch; Component Kind: Standard; ComponentLink2Description: Datasheet; ComponentLink2URL: https://b2b-api.panasonic.eu/file_stream/pids/fileversion/2431; Device Class L1: Electromechanical; Device Class L2: Switches; Device Class L3: Tactile Switches; Digikey Description: SWITCH TACTILE SPST-NO 0.05A 12V; Height: 1.55mm; Lead Free: Yes; Library Name: Altium Content Vault; Manuf Part Number: EVQ-P7J01P; Manufacturer Part Number: EVQ-P7J01P; Mount: Surface Mount; Mouser Description: Tactile Switches 3.5x2.9mm Right Ang Light Touch Switch; Octopart: https://octopart.com/evq-p7j01p-panasonic-29917078; Package: 0; RoHS: TRUE; Supplier: Farnell; SupplierID: Farnell; Temperature: -20°C to +70°C; Temperature Range High: +70°C; Temperature Range Low: -20°C; Throw Configuration: SPST-NO; Value: EVQ-P7J01P; Voltage Rating Dc: 12V
T1 = MCH_000066: Test 5 pins row pit 50; ManufacturerID: no manufacturer; Part Category: Virtual/Test point; Part Select: SMCH0002000100000000; Active: 1; Cat1: Virtual; Cat2: Test point; Database Table Name: _Mechanicals; Designer: Jiri  Cengery; Exact price 2: 0; FIT: 9,99999974737875E-6; Function Type: Test points; ID: 3861; LastRevisionNote: new release; Max Oper Temperature: 200; Min Oper Temperature: -60; Alternative: 1; ComponentLink1Description: Datasheet; Exact price: 1; Exact price 3: 0; LastRevisionDate: 29.2.2016; Library Name: Lib_Components_SQL.DbLib; Part Number: MCH_000066; Part type: MCH; PartNumber: MCH_000066; PartQuantity: 1; PCB Status: Virtual; Preference: 0; Price: 0; Price 2: 0; Price 3: 0; Publishied: 29.2.2016; RoHS: 1; Simulation: nosim; Stock: 0; Supplier: N/A; Supplier2: N/A; Supplier3: N/A; SupplierID: no supplier; SupplierID2: no supplier; SupplierID3: no supplier; SupplierURL: N/A; SupplierURL2: N/A; SupplierURL3: N/A; Value: TP40_5_pit50; Publisher: Jiri Cengery
TP1 = MCH_000001: Test point 1mm; ManufacturerID: no manufacturer; Part Category: Virtual/Test point; Part Select: SMCH0002000100000000; Active: 1; Cat1: Virtual; Database Table Name: _Mechanicals; Designer: Libor Polacek; FIT: 9,99999974737875E-6; ID: 887; LastRevisionNote: new release; Max Oper Temperature: 200; Min Oper Temperature: -60; Preference: 0; Alternative: 1; Cat2: Test point; ComponentLink1Description: Datasheet; Exact price: 1; Function Type: Test point; LastRevisionDate: 11.5.2011; Library Name: Lib_Components_SQL.DbLib; Part Number: MCH_000001; Part type: MCH; PartNumber: MCH_000001; PartQuantity: 1; PCB Status: Virtual; Price: 0; Price 2: 0; Price 3: 0; Publishied: 11.5.2011; Quantity: 1; RoHS: 1; Simulation: nosim; Stock: 0; Supplier: N/A; Supplier2: N/A; Supplier3: N/A; SupplierID: no supplier; SupplierID2: no supplier; SupplierID3: no supplier; SupplierURL: N/A; SupplierURL2: N/A; SupplierURL3: N/A; Value: TP40; Publisher: Libor Polacek
